# Altium schematic sheet Bowlong Machine.SchDoc("Bowlong Machine")
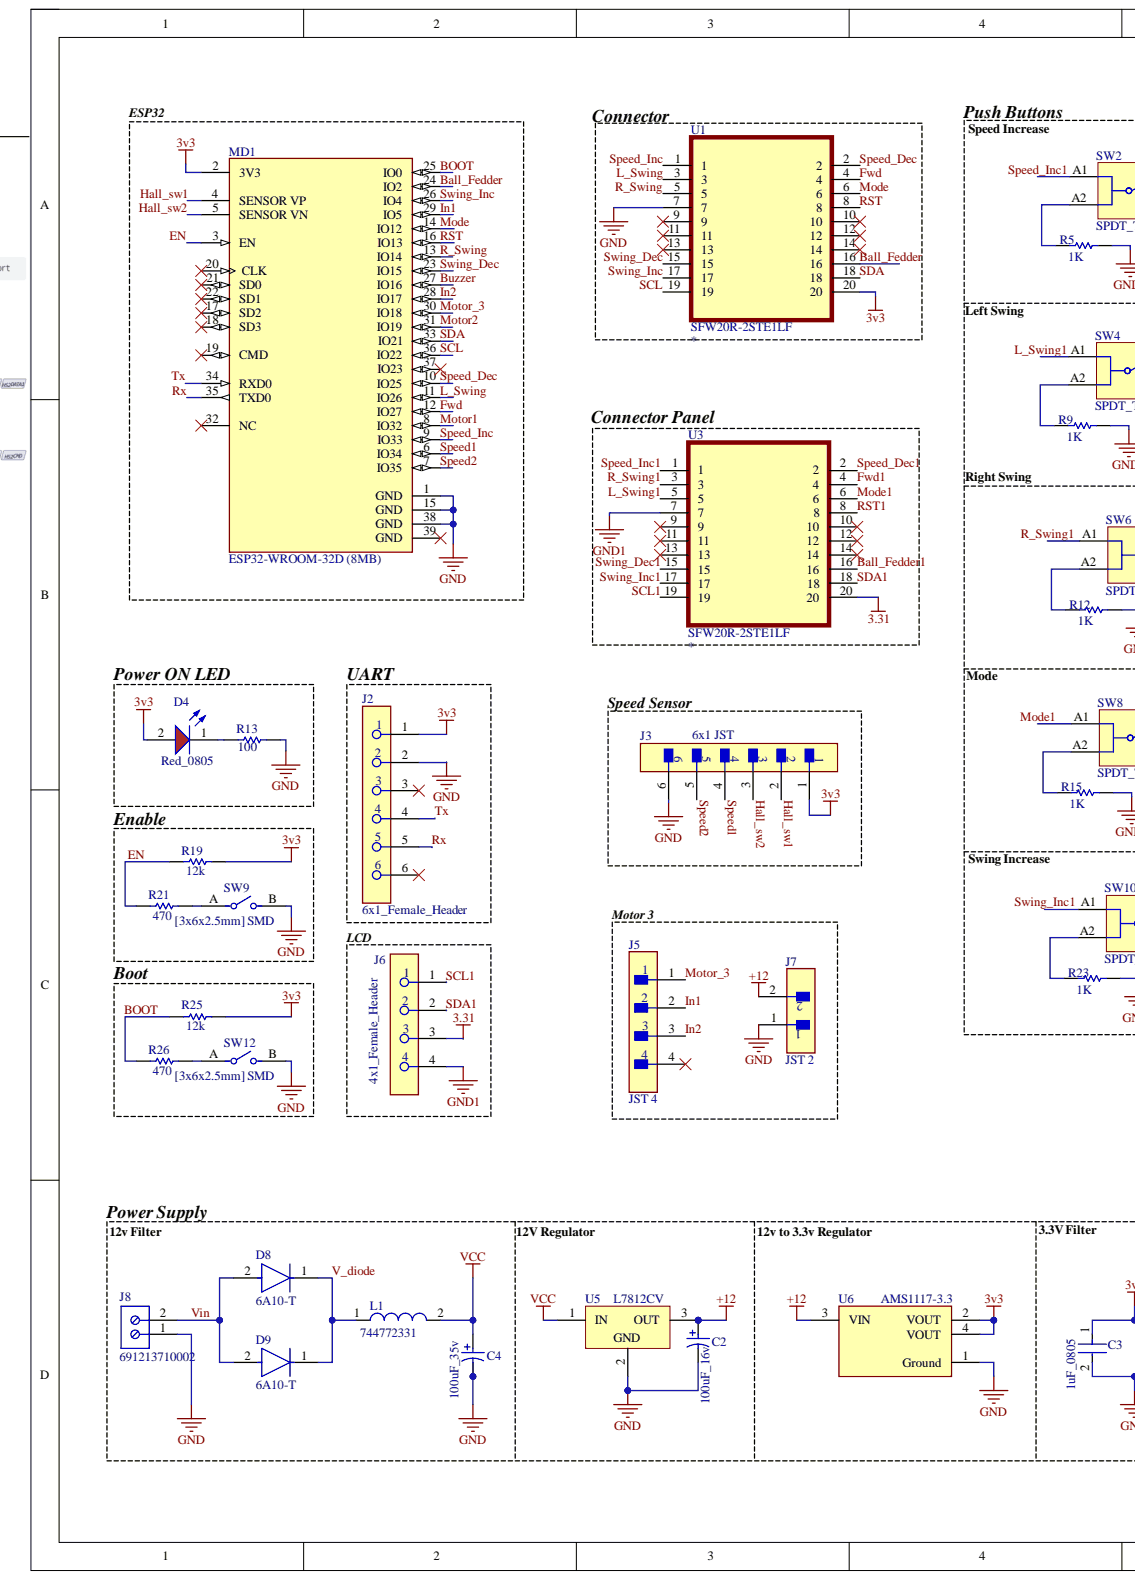
[diagram p0: Bowlong Machine.SchDoc, left]
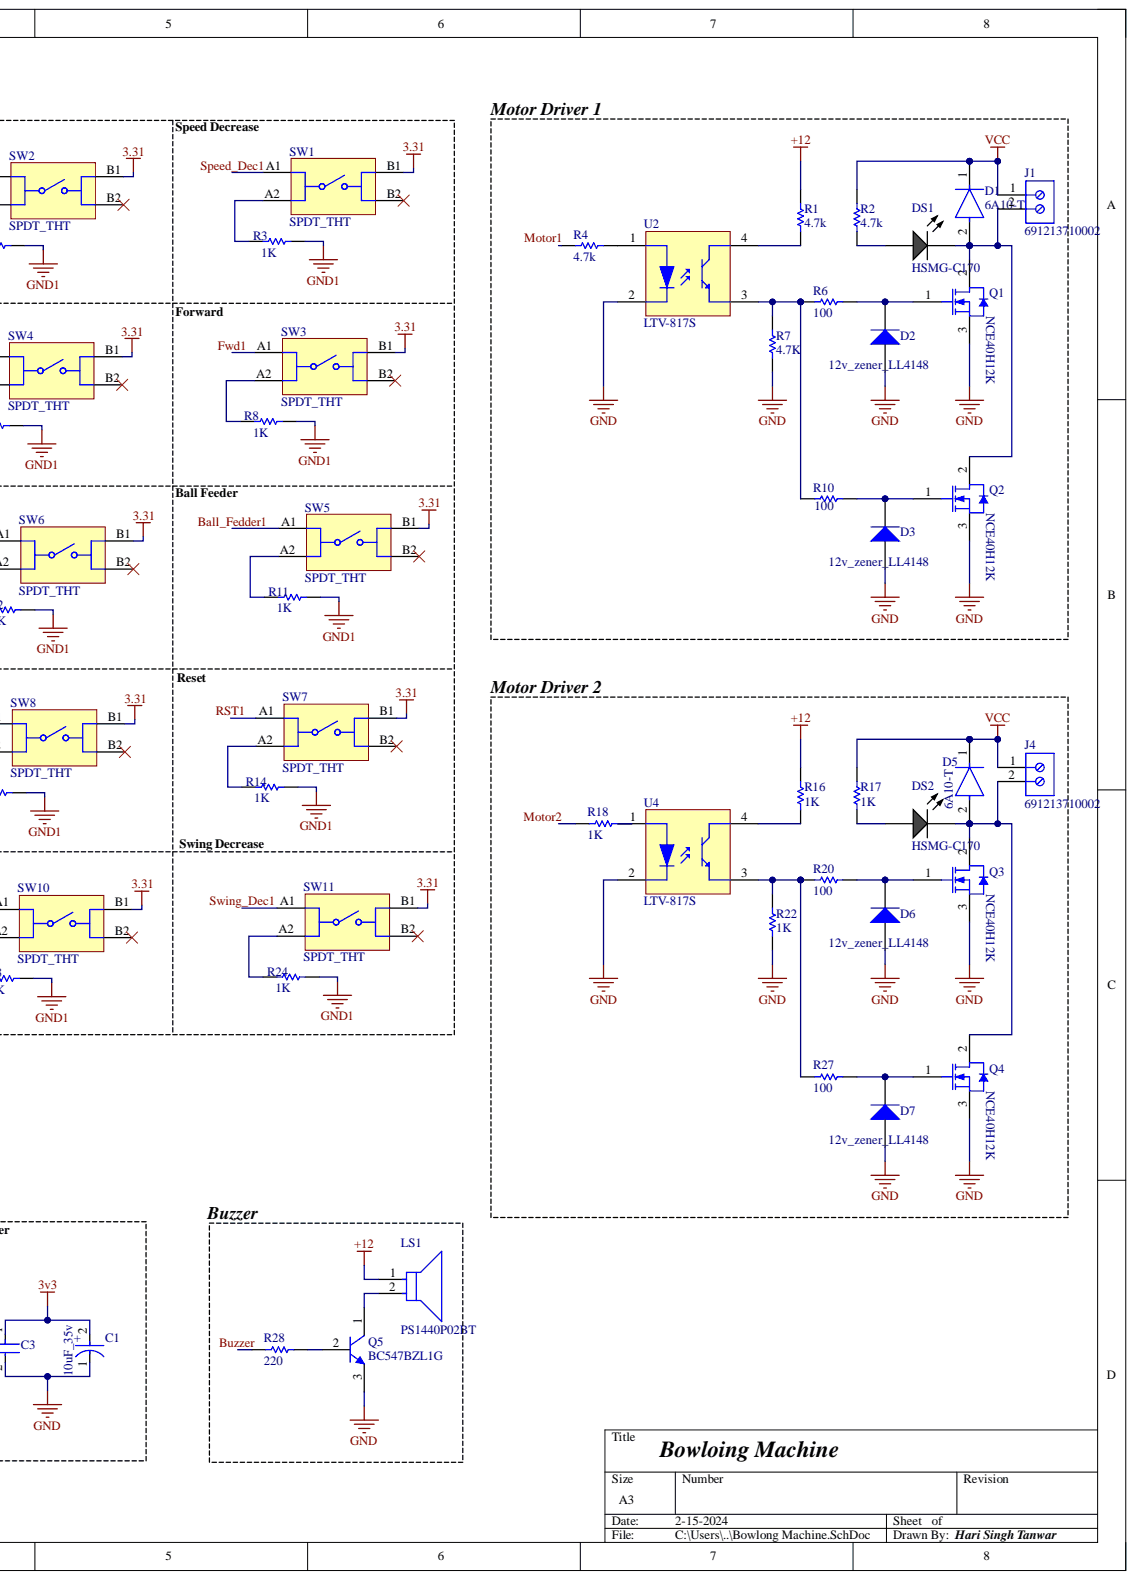
[diagram p1: Bowlong Machine.SchDoc, right]

## Parts
C1  10uF_35v  [FP-FK-D-MFG]
C2  100uF_16v  [PNSC-EEU-EE2G100-2_V]
C3  1uF_0805  [FP-CC0805-0_85-MFG]
C4  100uF_35v  [PNSC-EEU-EE2G100-2_V]
D1  6A10-T  [FP-R-6-MFG]
D2  12v_zener_LL4148  [FAIR-SOD80-2_V]
D3  12v_zener_LL4148  [FAIR-SOD80-2_V]
D4  Red_0805  [WL-SMCW_0805_150080xx75000]
D5  6A10-T  [FP-R-6-MFG]
D6  12v_zener_LL4148  [FAIR-SOD80-2_V]
D7  12v_zener_LL4148  [FAIR-SOD80-2_V]
D8  6A10-T  [FP-R-6-MFG]
D9  6A10-T  [FP-R-6-MFG]
DS1  HSMG-C170  [AVAG-HSMX-C170_V]
DS2  HSMG-C170  [AVAG-HSMX-C170_V]
J1  691213710002  [691213710002]
J2  6x1_Female_Header  [FP-SSW-106-02-F-S-MFG]
J3  6x1 JST  [FP-B6B-EH-A_LF_SN-MFG]
J4  691213710002  [691213710002]
J5  JST 4  [FP-B4B-ZR_LF_SN-MFG]
J6  4x1_Female_Header  [FP-2212S-04SG-85-MFG]
J7  JST 2  [FP-B2B-XH-A_LF_SN-MFG]
J8  691213710002  [691213710002]
L1  744772331  [WE-TI-L]
LS1  PS1440P02BT  [FP-PS1440P02BT-MFG]
MD1  ESP32-WROOM-32D (8MB)  [FP-ESP32-WROOM-32D_8MB-MFG]
Q1  NCE40H12K  [INF-PG-TO252-3-313_N]
Q2  NCE40H12K  [INF-PG-TO252-3-313_N]
Q3  NCE40H12K  [INF-PG-TO252-3-313_N]
Q4  NCE40H12K  [INF-PG-TO252-3-313_N]
Q5  BC547BZL1G  [ONSC-TO-92-3-29-11_AM_BL]
R1  4.7k  [RESC2013X50X30LL20T20]
R2  4.7k  [RESC2013X50X30LL20T20]
R3  1K  [RESC2013X50X30LL20T20]
R4  4.7k  [RESC2013X50X30LL20T20]
R5  1K  [RESC2013X50X30LL20T20]
R6  100  [RESC2013X50X30LL20T20]
R7  4.7K  [RESC2013X50X30LL20T20]
R8  1K  [RESC2013X50X30LL20T20]
R9  1K  [RESC2013X50X30LL20T20]
R10  100  [RESC2013X50X30LL20T20]
R11  1K  [RESC2013X50X30LL20T20]
R12  1K  [RESC2013X50X30LL20T20]
R13  100  [RESC2013X50X30LL20T20]
R14  1K  [RESC2013X50X30LL20T20]
R15  1K  [RESC2013X50X30LL20T20]
R16  1K  [RESC2013X50X30LL20T20]
R17  1K  [RESC2013X50X30LL20T20]
R18  1K  [RESC2013X50X30LL20T20]
R19  12k  [RESC2013X50X30LL20T20]
R20  100  [RESC2013X50X30LL20T20]
R21  470  [RESC2013X50X30LL20T20]
R22  1K  [RESC2013X50X30LL20T20]
R23  1K  [RESC2013X50X30LL20T20]
R24  1K  [RESC2013X50X30LL20T20]
R25  12k  [RESC2013X50X30LL20T20]
R26  470  [RESC2013X50X30LL20T20]
R27  100  [RESC2013X50X30LL20T20]
R28  220  [RESC2013X50X30LL20T20]
SW1  SPDT_THT  [4301x60707x6]
SW2  SPDT_THT  [4301x60707x6]
SW3  SPDT_THT  [4301x60707x6]
SW4  SPDT_THT  [4301x60707x6]
SW5  SPDT_THT  [4301x60707x6]
SW6  SPDT_THT  [4301x60707x6]
SW7  SPDT_THT  [4301x60707x6]
SW8  SPDT_THT  [4301x60707x6]
SW9  [3x6x2.5mm] SMD  [FP-EVQ-PE105K-MFG]
SW10  SPDT_THT  [4301x60707x6]
SW11  SPDT_THT  [4301x60707x6]
SW12  [3x6x2.5mm] SMD  [FP-EVQ-PE105K-MFG]
U1  SFW20R-2STE1LF  [FCI_SFW20R-2STE1LF]
U2  LTV-817S  [LTST-LTV-817S-4_V]
U3  SFW20R-2STE1LF  [FCI_SFW20R-2STE1LF]
U4  LTV-817S  [LTST-LTV-817S-4_V]
U5  L7812CV  [TO220]
U6  AMS1117-3.3  [FP-AMS1117-IPC_C]

## Nets
3.31: J6.3 SW1.B1 SW2.B1 SW3.B1 SW4.B1 SW5.B1 SW6.B1 SW7.B1 SW8.B1 SW10.B1 SW11.B1 U3.20
3v3: C1.2 C3.1 D4.2 J2.1 J3.1 MD1.2(3V3) R19.1 R25.1 U1.20 U6.2(VOUT) U6.4(VOUT)
+12: C2.1 J7.2 LS1.1 R1.2 R16.2 U5.3 U6.3(VIN)
GND: C1.1 C2.2 C3.2 C4.2 D2.1 D3.1 D6.1 D7.1 J2.2 J3.6 J7.1 J8.1 MD1.1(GND) MD1.15(GND) MD1.38(GND) Q1.3 Q2.3 Q3.3 Q4.3 Q5.3 R7.1 R13.1 R22.1 SW9.B SW12.B U1.7 U2.2 U4.2 U5.2 U6.1(Ground)
GND1: J6.4 R3.1 R5.1 R8.1 R9.1 R11.1 R12.1 R14.1 R15.1 R23.1 R24.1 U3.7
VCC: C4.1 D1.1 D5.1 J1.1 J4.1 L1.2 R2.2 R17.2 U5.1
Ball_Fedder: MD1.24(IO2) U1.16
Ball_Fedder1: SW5.A1 U3.16
BOOT: MD1.25(IO0) R25.2 R26.2
Buzzer: MD1.27(IO16) R28.1
EN: MD1.3(EN) R19.2 R21.2
Fwd: MD1.12(IO27) U1.4
Fwd1: SW3.A1 U3.4
Hall_sw1: J3.2 MD1.4(SENSOR_VP)
Hall_sw2: J3.3 MD1.5(SENSOR_VN)
In1: J5.2 MD1.29(IO5)
In2: J5.3 MD1.28(IO17)
L_Swing: MD1.11(IO26) U1.3
L_Swing1: SW4.A1 U3.5
Mode: MD1.14(IO12) U1.6
Mode1: SW8.A1 U3.6
Motor1: MD1.8(IO32) R4.1
Motor2: MD1.31(IO19) R18.1
Motor_3: J5.1 MD1.30(IO18)
R_Swing: MD1.13(IO14) U1.5
R_Swing1: SW6.A1 U3.3
RST: MD1.16(IO13) U1.8
RST1: SW7.A1 U3.8
Rx: J2.5 MD1.35(TXD0)
SCL: MD1.36(IO22) U1.19
SCL1: J6.1 U3.19
SDA: MD1.33(IO21) U1.18
SDA1: J6.2 U3.18
Speed1: J3.4 MD1.6(IO34)
Speed2: J3.5 MD1.7(IO35)
Speed_Dec: MD1.10(IO25) U1.2
Speed_Dec1: SW1.A1 U3.2
Speed_Inc: MD1.9(IO33) U1.1
Speed_Inc1: SW2.A1 U3.1
Swing_Dec: MD1.23(IO15) U1.15
Swing_Dec1: SW11.A1 U3.15
Swing_Inc: MD1.26(IO4) U1.17
Swing_Inc1: SW10.A1 U3.17
Tx: J2.4 MD1.34(RXD0)
V_diode: D8.1 D9.1 L1.1
Vin: D8.2 D9.2 J8.2
NetD1_2: D1.2 DS1.2 J1.2 Q1.2 Q2.2
NetD2_2: D2.2 Q1.1 R6.2
NetD3_2: D3.2 Q2.1 R10.2
NetD4_1: D4.1 R13.2
NetD5_2: D5.2 DS2.2 J4.2 Q3.2 Q4.2
NetD6_2: D6.2 Q3.1 R20.2
NetD7_2: D7.2 Q4.1 R27.2
NetDS1_1: DS1.1 R2.1
NetDS2_1: DS2.1 R17.1
NetLS1_2: LS1.2 Q5.1
NetQ5_2: Q5.2 R28.2
NetR1_1: R1.1 U2.4
NetR3_2: R3.2 SW1.A2
NetR4_2: R4.2 U2.1
NetR5_2: R5.2 SW2.A2
NetR6_1: R6.1 R7.2 R10.1 U2.3
NetR8_2: R8.2 SW3.A2
NetR9_2: R9.2 SW4.A2
NetR11_2: R11.2 SW5.A2
NetR12_2: R12.2 SW6.A2
NetR14_2: R14.2 SW7.A2
NetR15_2: R15.2 SW8.A2
NetR16_1: R16.1 U4.4
NetR18_2: R18.2 U4.1
NetR20_1: R20.1 R22.2 R27.1 U4.3
NetR21_1: R21.1 SW9.A
NetR23_2: R23.2 SW10.A2
NetR24_2: R24.2 SW11.A2
NetR26_1: R26.1 SW12.A

Not connected: J2 3 6; J5 4; MD1 17(SD2) 18(SD3) 19(CMD) 20(CLK) 21(SD0) 22(SD1) 32(NC) 37(IO23) 39(GND); SW1 B2; SW2 B2; SW3 B2; SW4 B2; SW5 B2; SW6 B2; SW7 B2; SW8 B2; SW10 B2; SW11 B2; U1 9 10 11 12 13 14; U3 9 10 11 12 13 14

## Library data of the parts
C1 = CMP-05427-000002-1: CAP ALUM 47UF 20% 35V SMD; Manufacturer: Panasonic; Manufacturer Part Number: EEE-FK1V470P; Automotive Grade: Grade 2; Dissipation Factor: 12%; Esr: 0.36Ω; Leakage Current: 16.45uA; Life: 2000Hours; Material: Aluminum; Ripple Current: 240mA; Automotive: Yes; Capacitance: 47uF; Category: Cap; Component Kind: Standard; ComponentLink2Description: Datasheet; ComponentLink2URL: https://industrial.panasonic.com/cdbs/www-data/pdf/RDE0000/ABA0000C1181.pdf; Device Class L1: Passive Components; Device Class L2: Capacitors; Device Class L3: Aluminum Electrolytic Capacitors; Digikey Description: CAP ALUM 47UF 20% 35V SMD; Height: 6.1mm; Lead Free: Yes; Library Name: Altium Content Vault; Mouser Description: Capacitor Aluminum Electrolytic 47uF 35 Volt 20% (6.3 X 5.8mm) Surface Mount 240mA 2000h 105C Automotive; Octopart: https://octopart.com/eeefk1v470p-panasonic-4642848; Package: FK-D; RoHS: TRUE; Temperature: -55°C to +105°C; Temperature Range High: +105°C; Temperature Range Low: -55°C; Tolerance: 20%; Value: 47uF; Voltage Rating: 35V
C2 C4 = CMP-0736-00002-1: Aluminum Electrolytic Capacitor, 10 uF +/- 20%, 400 V, -25 to 105 degC, 2-Pin 10 x 10 x 22 mm Through-hole, RoHS, Tape and Reel; Manufacturer: Panasonic; PartNumber: EEU-EE2G100; Cap.: 10 uF; Capacitance Tolerance: +/- 20%; Rated Voltage: 400 V; Ripple Current: 430 mA; Component Kind: Standard; ComponentLink1Description: Manufacturer URL; ComponentLink1URL: http://industrial.panasonic.com/; ComponentLink2Description: Datasheet; ComponentLink2URL: http://industrial.panasonic.com/www-data/pdf/ABA0000/ABA0000CE113.pdf; ComponentLink3Description: Package Specification; ComponentLink3URL: http://industrial.panasonic.com/www-data/pdf/ABA0000/ABA0000PE245.pdf; DatasheetVersion: Nov-12; Library Name: Altium Content Vault; Mounting Technology: Through Hole; PackageDescription: 2-Pin Through-hole, Base 10 x 10 mm, Height 22 mm; PackageReference: EEU-EE2G100-2; PackageVersion: Nov-12; Packing: Tape and Reel; RoHS: TRUE; Temp. Range: -25 to 105 degC
C3 = CMP-2100-03606-3: CAP CER 0.1UF 50V X7R 0805; Manufacturer: Yageo; Manufacturer Part Number: CC0805KRX7R9BB104; Capacitance: 100 nF; Material: Ceramic; Tolerance: 0.1; Value: 100 nF; Automotive: No; Category: Cap; Component Kind: Standard; ComponentLink2Description: Datasheet; ComponentLink2URL: http://www.yageo.com/documents/recent/UPY-GPHC_Y5V_6.3V-to-50V_8.pdf; Device Class L1: Passive Components; Device Class L2: Capacitors; Device Class L3: Ceramic Capacitors; Digikey Description: CAP CER 0.1UF 50V X7R 0805; Footprint Url: http://www.yageo.com/exep/pages/download/literatures/UPY-C_GEN_24.pdf; Height: 0.95mm; Ipc Land Pattern Name: CAPC200125X85; Lead Free: Yes; Library Name: Altium Content Vault; Mouser Description: Multilayer Ceramic Capacitors MLCC - SMD/SMT 100nF 50V X7R 10%; Octopart: https://octopart.com/cc0805krx7r9bb104-yageo-192993; Package: CC0805-0.85; RoHS: TRUE; Temperature: -30°C to +85°C; Temperature Characteristic: X7R; Temperature Range High: +85°C; Temperature Range Low: -30°C; Voltage Rating: 50 V
D1 D5 D8 D9 = CMP-12791-000038-1: DIODE GEN PURP 1KV 6A R6; Manufacturer: Diodes Inc.; Max Junction Temp: +175°C; Max Repetitive Reverse Voltage: 1000V; Max Surge Forward Current: 400A; Peak Reverse Current: 10uA; Automotive: No; Category: Diode; Component Kind: Standard; ComponentLink2Description: Datasheet; ComponentLink2URL: https://www.diodes.com/assets/Datasheets/ds28009.pdf; Device Class L1: Discrete Semiconductors; Device Class L2: Diodes; Device Class L3: Rectifier Diodes; Digikey Description: DIODE GEN PURP 1KV 6A R6; Footprint Url: https://www.diodes.com/assets/Package-Files/R-6.pdf; Forward Current: 6A; Height: 9.1mm; Ipc Land Pattern Name: DIOAD2054W145L885D885; Lead Free: Yes; Library Name: Altium Content Vault; Manufacturer Part Number: 6A10-T; Mouser Description: Rectifiers 1000V 6A; Octopart: https://octopart.com/6a10-t-diodes+inc.-55426548; Package: R-6; Reverse Voltage: 1000V; RoHS: TRUE; Temperature: -65°C to +175°C; Temperature Range High: +175°C; Temperature Range Low: -65°C
D2 D3 D6 D7 = CMP-2000-05115-2: Small Signal Diode, 100 V, 0.2 A, -55 to 175 degC, 2-Pin SOD80, RoHS, Tape and Reel; Manufacturer: Fairchild; Average Forward Current-Max: 200mA; Forward Voltage: 1V; Power Dissipation: 500mW; Reverse Recovery Time-Max: 1ns; Reverse Voltage-Max [Vrrm]: 100V; Component Kind: Standard; Datasheet URL: https://www.fairchildsemi.com/datasheets/LL/LL4148.pdf; Datasheet Version: Rev. 1.8, 01/2016; Library Name: Altium Content Vault; Manufacturer URL: https://www.fairchildsemi.com/
D4 = CMP-1426-00011-2: NexarPartId: 29717781; Color: Red; Dominant Wavelength: 625nm; Forward Current: 100mA; Forward Voltage: 2V; Lens Style: Clear, Rectangular; Luminous Intensity: 150mcd; Max Reverse Voltage (DC): 5V; Peak Wavelength: 630nm; Test Current: 20mA; Viewing Angle: 140°; Case Code (Imperial): 0805; Case Code (Metric): 2012; Case/Package: 0805; Component Kind: Standard; Height: 700µm; Length: 2mm; Library Name: Altium Content Vault; Max Operating Temperature: 85°C; Min Operating Temperature: -40°C; Mount: Surface Mount; Packaging: Tape and Reel; REACH SVHC: Unknown; RoHS: Compliant; Wavelength: 625nm; Width: 1.25mm
DS1 DS2 = CMP-2000-05062-1: Chip LED, Green, 2.2 V, -40 to 85 degC, 2-Pin SMD, Pb-Free, Tape and Reel; Manufacturer: Avago; Bulb Size: 1.25mmx1.4mm; Dominant Wavelength: 572nm; External Depth: 0.8mm; External Length / Height: 2mm; External Width: 1.25mm; Forward Current If: 20mA; Forward Voltage: 2.2V; Forward Voltage VF Max: 2.6V; LED Type: Standard; Lens Shape: Rectangular; Light Area Height: 1mm; Luminous Intensity: 15mcd; Luminous Intensity Min: 4mcd; No. of Pins: 2; Opto Mounting Orientation: Top; Peak Wavelength: 570nm; PIV Max: 5V; SVHC: NoSVHC(16-Jun-2014); Viewing Angle: 170deg; Component Kind: Standard; Datasheet URL: https://datasheet.ciiva.com/29280/180495-da-01-en-chip-led-hsmg-c170-gelb-gruen-29280971.pdf; Datasheet Version: Oct-08; Dominant Wavelength Typ: 572nm; Forward Current If Max: 20mA; LED Colour: Green; LED Mounting: SMD; Library Name: Altium Content Vault; Luminous Intensity Typ: 15mcd; Manufacturer URL: http://www.avagotech.com/; Mounting Type: SMD; MSL: MSL2A-4weeks; Operating Temperature Max: 85degC; Operating Temperature Min: -30degC; Operating Temperature Range: -30degCto+85degC; Opto Case Style: SMD; Package Description: 2-Pin Surface Mount Device, Body 2 x 1.25 mm, Height 0.8 mm; Package Reference: HSMX-C170; Packaging: CutTape; Peak Forward Current: 20mA; Storage Temperature Max: 85degC; Storage Temperature Min: -40degC; Voltage Vf Typ: 2.2V; Wavelength Typ: 570nm
J1 J4 J8 = CMP-1502-02526-2: NexarPartId: 8917718; Current: 10A; Number of Contacts: 2; Number of Levels: 1; Pitch: 5.0038mm; Plating: Brass, Steel; Stripping Length: -7mm; Voltage: 300V; Wire Gauge (Max): 26AWG; Wire Gauge (Min): 16AWG; Color: Green; Component Kind: Standard; Contact Material: Brass; Contact Plating: Tin; Current Rating: 10A; Height: 10mm; Housing Material: Nylon; Lead Pitch: 5mm; Length: 8.3mm; Library Name: Altium Content Vault; Max Operating Temperature: 105°C; Min Operating Temperature: -40°C; Mount: Through Hole; Number of Pins: 2; Number of Positions Per Level: 2; Packaging: Box; REACH SVHC: Unknown; RoHS: Compliant; Termination: Screw; Voltage Rating: 300V; Width: 10.6mm; Wire/Cable Gauge: 26AWG
J2 = CMP-1023-00361-2: CONN RCPT 6POS 0.1 GOLD PCB; Contact Resistance: 10mR; Gender: Female; Mating Cycles: 100; Max Current Rating: 6.9A; Max Voltage Rating (AC): 550V; Number of Contacts: 6; Pitch: 2.54mm; Color: Black; Component Kind: Standard; Contact Material: Bronze; Contact Plating: Gold; Depth: 2.41mm; Housing Color: Black; Housing Material: Polymer; Length: 15.75mm; Library Name: Altium Content Vault; Max Operating Temperature: 125°C; Min Operating Temperature: -55°C; Number of Rows: 1; Orientation: Vertical; Packaging: Bulk; Radiation Hardening: No; RoHS Compliant: Yes; Termination: Solder
J3 = CMP-17439-000293-1: CONN HEADER VERT 6POS 2.5MM; Current Rating: 3A; Gender: Male; Mated Stacking Heights: 8.1mm; Number of Contacts: 6; Voltage Rating: 250V; Color: Natural; Component Kind: Standard; Connector Type: Header; Contact Finish - Mating: TIN; Contact Material: Brass; Contact Mating Length: 0.13inch; Contact Plating: Tin; Contact Shape: Square; Contact Type: Male Pin; Fastening Type: Friction; Flammability Rating: UL94 V-0; Housing Color: White; Insulation Color: Natural; Insulation Material: Nylon; Lead Free: Lead Free; Library Name: Altium Content Vault; Mount: Through Hole; Number of Positions: 6; Number of Rows: 1; Orientation: Straight; Packaging: Bulk; Pitch: 2.5mm; Series: EH; Termination: Solder
J5 = CMP-17439-000033-1: CONN HEADER VERT 4POS 1.5MM; Body Plating: Tin; Connector Type: Male Pin; Contact Resistance: 30mR; Current Rating: 1A; Gender: Male; Insulation Resistance: 500MR; Max Voltage Rating (AC): 50V; Number of Contacts: 4; Panel Thickness: 3.5mm; Color: Natural; Component Kind: Standard; Contact Material: Brass; Contact Mating Length: 0.094inch; Contact Plating: Tin; Contact Type: Male Pin; Depth: 3.5mm; Fastening Type: Detent; Flammability Rating: UL94 V-0; Housing Color: Beige; Housing Material: Polyamide; Lead Free: Lead Free; Lead Pitch: 1.5mm; Length: 7.5mm; Library Name: Altium Content Vault; Material: Nylon; Max Current Rating: 1A; Max Operating Temperature: 85°C; Min Operating Temperature: -25°C; Mount: Through Hole; Number of Positions: 4; Number of Rows: 1; Orientation: Straight; Packaging: Bulk; Pitch: 1.5mm; REACH SVHC: No SVHC; Series: ZH; Termination: Solder; Voltage Rating: 50V; Voltage Rating (AC): 50V; Voltage Rating (DC): 50V
J6 = CMP-12414-000003-1: Header, Rectangular, 4 Positions, 2.54mm Pitch, Receptacle, Vertical, Through-Hole; Insulation Resistance: 1GR; Number of Contacts: 4; Component Kind: Standard; Contact Material: Bronze; Contact Plating: Gold; Flammability Rating: UL94 V-0; Gender: Receptacle; Height: 0.35inch; Length: 0.43inch; Library Name: Altium Content Vault; Mount: Through Hole; Number of Rows: 1; REACH SVHC: No SVHC; RoHS Compliant: Yes; Termination: Through Hole; Weight: 0.11lb; Width: 0.12inch
J7 = CMP-2000-05888-3: CONN HEADER VERT 2POS 2.5MM; Connector Type: Male Pin; Contact Resistance: 20mR; Current Rating: 3A; Insulation Resistance: 1GR; Max Voltage Rating (AC): 250V; Number of Contacts: 2; Wire/Cable Gauge: 22AWG; Color: Natural; Component Kind: Standard; Contact Material: Brass; Contact Plating: Tin; Contact Type: Male Pin; Depth: 5.75mm; Fastening Type: Detent; Housing Color: White; Housing Material: Polyamide; Lead Free: Lead Free; Lead Pitch: 2.5mm; Length: 7.4mm; Library Name: Altium Content Vault; Max Current Rating: 3A; Max Operating Temperature: 85°C; Max Voltage Rating (DC): 250V; Min Operating Temperature: -25°C; Mount: Through Hole; Number of Positions: 2; Number of Rows: 1; Orientation: Straight; Packaging: Bulk; Pitch: 2.5mm; REACH SVHC: No SVHC; Series: XH; Termination: Solder; Voltage Rating: 250V; Voltage Rating (AC): 250V
L1 = CMP-1405-00029-1: Radial Leaded Wire Wound Inductor WE-TI, L=330 µH; Manufacturer: Wuerth Elektronik; Inductance: 330 ÂµH; Component Kind: Standard; ComponentLink1Description: Manufacturer URL; ComponentLink1URL: http://www.we-online.com; ComponentLink2Description: Datasheet; ComponentLink2URL: http://www.we-online.de/katalog/media/pdf/744772331.pdf; Library Name: Altium Content Vault; PackageDescription: THT Inductor, 2-Leads, Body 7.8 x 7.8 mm; PackageReference: WE-TI-L; PartNumber: 744772331
LS1 = CMP-08413-000003-1: AUDIO PIEZO TRANSDUCER 30V TH; Frequency: 4kHz; Number of Terminals/Pins: 2; Operating Supply Voltage: 3V; Washable: No; Component Kind: Standard; Diameter: 14mm; Height: 8mm; Lead Free: Lead Free; Library Name: Altium Content Vault; Max Operating Temperature: 70°C; Min Operating Temperature: -10°C; Mount: Through Hole; Package Quantity: 350; Packaging: Tape and Reel; RoHS Compliant: Yes; Self Resonant Frequency: 4kHz; Termination: PC Pins; Voltage Rating (DC): 3V
MD1 = CMP-194065-000011-1: RX TXRX MOD WIFI TRACE ANT SMD; Data Rate: 150Mbps; Frequency: 2.5GHz; Interface: I2C; Memory Size: 536KB; Sensitivity: -98dBm; Case/Package: SMD/SMT; Component Kind: Standard; Library Name: Altium Content Vault; Max Operating Temperature: 85°C; Min Operating Temperature: -40°C; Mount: Surface Mount; Packaging: Tape and Reel
Q1-Q4 = CMP-1164-00353-1: N-Channel CoolMOS C6 Power-Transistor, 600 V VDS, 8.1 A ID, -55 to 150 degC, PG-TO252-3-313, Reel, Green; Manufacturer: Infineon; PartNumber: IPD60R520C6; Green: TRUE; ID (max): 8.1Â A; IDpuls (max): 22Â A; Polarity: N; Ptot (max): 66Â W; QG: 23.4Â nC; RDS (on) (max): 520Â mOhm; Rth: 1.9Â K/W; RthJA (max): 62Â K/W; VDS (max): 600Â V; VGS(th) (max): 3.5Â V; VGS(th) (min): 2.5Â V; Component Kind: Standard; ComponentLink1Description: Manufacturer URL; ComponentLink1URL: http://www.infineon.com/; ComponentLink2Description: Datasheet; ComponentLink2URL: http://www.infineon.com/dgdl/IPD60R520C6_2.0.pdf?folderId=db3a3043163797a6011638933eda014b&fileId=db3a304323b87bc20123e676d18358fb; ComponentLink3Description: Package Specification; ComponentLink3URL: http://www.infineon.com/dgdl/PG-TO252-3-313-Package_Overview.pdf?folderId=db3a30432b57a660012b81890ade72d2&fileId=db3a30432b57a660012b8193af2872eb; DatasheetVersion: Rev. 2.0; Library Name: Altium Content Vault; Mounting Technology: Surface Mount; PackageDescription: 3-Pin Plastic (Green) Transistor Single Outline Package, 6.5 x 6.12 mm Body, 2.28 mm Pitch; PackageReference: PG-TO252-3-313; PackageVersion: Oct-10; Packing: Reel; RthJC (max): 1.9Â K/W; Technology: CoolMOS C6
Q5 = CMP-1048-01604-1: Amplifier Transistor, NPN Silicon, 3-Pin TO-92, Pb-Free, Ammo Box; Manufacturer: On Semiconductor; fT Min (MHz): 150; IC Continuous (A): 0.1; Component Kind: Standard; ComponentLink1Description: Manufacturer URL; ComponentLink1URL: http://www.onsemi.com/; ComponentLink2Description: Datasheet; ComponentLink2URL: http://www.onsemi.com/pub_link/Collateral/BC546-D.PDF; ComponentLink3Description: Package Specification; ComponentLink3URL: http://www.onsemi.com/pub_link/Collateral/MAC97-D.PDF; DatasheetVersion: Rev. 7; Library Name: Altium Content Vault; Mounting Technology: Through Hole; PackageDescription: 3-Pin Transistor Outline, Body 4.825 x 3.685 mm, Pitch 1.27 mm; PackageReference: TO-92-3-29-11_AM_BL; PackageVersion: Rev. AM; Packing: Ammo Box; PartNumber: BC547BZL1G; Polarity: NPN; RoHS: TRUE
R1-R28 = CMP-2001-04896-1: Manufacturer: Vishay Dale; Part Number: CRCW08050000Z0EA; Component Kind: Standard; Library Name: Altium Content Vault
SW1-SW8 SW10 SW11 = CMP-1453-00013-2: NexarPartId: 38794740; Actuator Material: Liquid Crystal Polymer, Polymer; Contact Current Rating: 50mA; Illumination Type: Illuminated, Non-Illuminated; Insulation Resistance: 100MΩ; Mechanical Life: 1Mcycles; Switch Function: Off-Mom; Switch Travel: 250µm; Throw Configuration: SPST; Voltage Rating: 12V; Color: Black; Component Kind: Standard; Contact Material: Steel; Contact Plating: Silver; Current Rating: 50mA; Diameter: 3mm; Height: 7mm; Length: 6.2mm; Library Name: Altium Content Vault; Max Operating Temperature: 85°C; Min Operating Temperature: -40°C; Mount: Through Hole; Packaging: Bulk; REACH SVHC: No SVHC; RoHS: Compliant; Termination: PC Pin; Width: 6.2mm
SW9 SW12 = CMP-00287-39857596-1: EVQ-PE105K Switch Tactile N.O. SPST Rectangular Button J-Bend 0.05A 12VDC 1N SMD Embossed Tape; Actuator Length: 1.5mm; Contact Current Rating: 50mA; Contact Resistance: 100mΩ; Electrical Life: 50kcycles; Illumination Type: Illuminated, Non-Illuminated; Max Voltage Rating (DC): 12V; Number of Positions: 2; Switch Function: Off-Mom; Switch Travel: 250µm; Color: Black; Component Kind: Standard; Contact Plating: Gold, Silver; Current Rating: 50mA; Depth: 7.2mm; Height: 5mm; Lead Free: Lead Free; Length: 6mm; Library Name: Altium Content Vault; Max Current Rating: 50mA; Max Operating Temperature: 85°C; Mechanical Life: 50kcycles; Min Operating Temperature: -30°C; Mount: Surface Mount; NexarPartId: 39857596; Operating Force: 1N; Orientation: Straight; Radiation Hardening: No; REACH SVHC: No SVHC; RoHS: Compliant; Termination: Gull Wing; Throw Configuration: SPST; Voltage Rating (DC): 12V; Width: 3.5mm
U1 U3 = CMP-008-000000-1: FFC/FPC CONNECTOR, RECEPTACLE 20POS 1ROW; Availability: Unavailable; Component Kind: Standard; Component Type: Standard; Design Item ID: SFW20R-2STE1LF; MP: SFW20R-2STE1LF; Package: None; Pin Count: 20; Price: None; Source: SFW20R-2STE1LF.SchLib; Library Name: Government Engineering College Bikaner
U2 U4 = CMP-2000-05204-1: Photocoupler - Phototransistor Output, 35 V VCEO, -50 to 110 degC, 4-Pin SMD, RoHS, Tape and Reel; Manufacturer: Lite-On; Collector Current (DC): 0.05A; Collector-Emitter Saturation Voltage: 0.2V; Collector-Emitter Voltage: 35V; Current Transfer Ratio: 600%; DELETED: Compliant; Fall Time: 18000ns; Forward Current: 50mA; Forward Voltage: 1.4V; Input Type: DC; Isolation Voltage: 5000V; Number of Elements: 1; Power Dissipation: 0.2W; Rad Hardened: No; Reverse Breakdown Voltage: 6V; Collector Current (DC) (Max): 0.05A; Component Kind: Standard; Datasheet URL: https://datasheet.ciiva.com/15118/getdatasheetpartid-147501-15118828.pdf; Datasheet Version: Jan-10; Library Name: Altium Content Vault; Manufacturer URL: http://www.us.liteon.com/; Mounting: SurfaceMount; Operating Temp Range: -30Cto100C; Operating Temperature Classification: Commercial; Output Device: Transistor; Output Type: DC; Package Type: PDIPSMD; Pin Count: 4; Rise Time: 18000ns
U5 = CMP-0244-00450-1: Positive Voltage Regulator, 12V, 3-Pin TO-220; Component Kind: Standard; ComponentLink1Description: Manufacturer URL; ComponentLink1URL: http://www.st.com; ComponentLink2Description: Datasheet; ComponentLink2URL: http://www.st.com/internet/com/TECHNICAL_RESOURCES/TECHNICAL_LITERATURE/DATASHEET/CD00000444.pdf; ComponentLink3Description: Package Specification; ComponentLink3URL: http://www.st.com/internet/com/TECHNICAL_RESOURCES/TECHNICAL_LITERATURE/PACKAGE_INFORMATION/CD00004649.pdf; DatasheetVersion: 16/11/2011; Library Name: Altium Content Vault; PackageDescription: TO, Thru-Hole, Vertical, Heatsink Mounted; 3 In-Line Leads; Pitch 2.54 mm; PackageReference: TO220; PackageVersion: ver3.0
U6 = CMP-209535-000001-1: LDO Voltage Regulators 1A, 3.3V; Manufacturer: Advanced Monolithic Systems; Dropout Voltage: 1.1V; Max Junction Temp: +125°C; Max Power Dissipation: 1.2W; Max Supply Voltage: 15V; Nominal Supply Current: 5mA; Number Of Outputs: 1; Output Type: Fixed; Standoff Height: 0.64mm; Automotive: No; Category: IC; Component Kind: Standard; ComponentLink2Description: Datasheet; ComponentLink2URL: http://www.advanced-monolithic.com/pdf/ds1117.pdf; Device Class L1: Integrated Circuits (ICs); Device Class L2: Power Management ICs; Device Class L3: Voltage Regulators - Linear; Height: 1.8mm; Ipc Land Pattern Name: SOT229P700X180-4; Lead Free: Yes; Library Name: Altium Content Vault; Manufacturer Part Number: AMS1117-3.3; Octopart: https://octopart.com/ams1117-3.3-advanced+monolithic+systems-25899300; Output Current: 1A; Output Voltage: 3.3V; Package: AMS1117; RoHS: TRUE; Temperature: -40°C to +125°C; Temperature Range High: +125°C; Temperature Range Low: -40°C
